# Altium schematic sheet CP2104.SchDoc(CP2104)
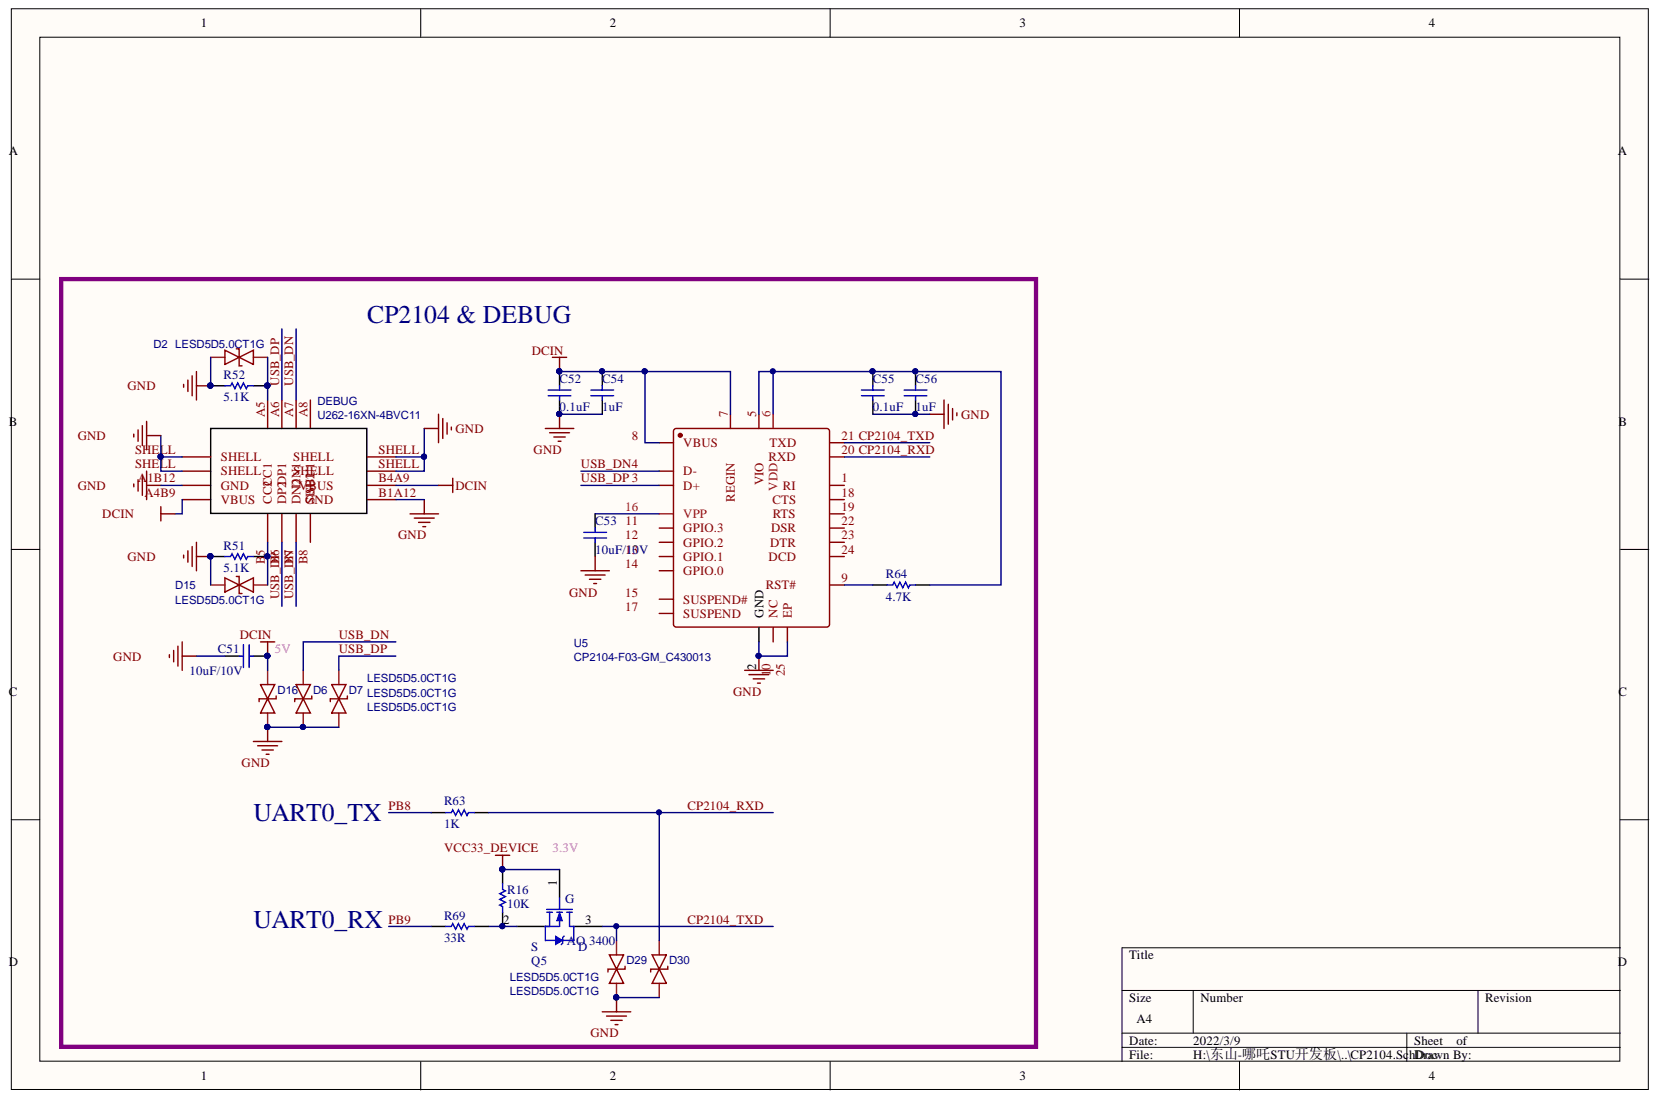
[diagram p0: CP2104.SchDoc]

## Parts
C51  10uF/10V  [0603]
C52  0.1uF  [0402]
C53  10uF/10V  [0603]
C54  1uF  [0402]
C55  0.1uF  [0402]
C56  1uF  [0402]
D2  LESD5D5.0CT1G  [SOD-523_L1.2-W0.8-LS1.6-BI]
D6  LESD5D5.0CT1G  [SOD-523_L1.2-W0.8-LS1.6-BI]
D7  LESD5D5.0CT1G  [SOD-523_L1.2-W0.8-LS1.6-BI]
D15  LESD5D5.0CT1G  [SOD-523_L1.2-W0.8-LS1.6-BI]
D16  LESD5D5.0CT1G  [SOD-523_L1.2-W0.8-LS1.6-BI]
D29  LESD5D5.0CT1G  [SOD-523_L1.2-W0.8-LS1.6-BI]
D30  LESD5D5.0CT1G  [SOD-523_L1.2-W0.8-LS1.6-BI]
DEBUG  U262-16XN-4BVC11  [TYPEC-12PIN - duplicate]
Q5  AO 3400  [SOT23]
R16  10K  [0402]
R51  5.1K  [0402]
R52  5.1K  [0402]
R63  1K  [0402]
R64  4.7K  [0402]
R69  33R  [0402]
U5  CP2104-F03-GM_C430013  [QFN-24_L4.0-W4.0-P0.50-TL-EP2.7]

## Nets
DCIN: C51.2 C52.1 C54.1 D16.2 DEBUG.A4B9(VBUS) DEBUG.B4A9 U5.7 U5.8(VBUS)
GND: C51.1 C52.2 C53.1 C54.2 C55.2 C56.2 D2.2 D6.1 D7.1 D15.2 D16.1 D29.2 D30.2 DEBUG.A1B12(GND) DEBUG.B1A12 DEBUG.SHELL R51.2 R52.2 U5.2(GND) U5.25(EP)
VCC33_DEVICE: Q5.1 R16.1
CP2104_RXD: D30.1 R63.2 U5.20
CP2104_TXD: D29.1 Q5.3 U5.21
PB8: R63.1
PB9: R69.1
USB_DN: D6.2 DEBUG.A7 DEBUG.B7(DN2) U5.4(D-)
USB_DP: D7.2 DEBUG.A6 DEBUG.B6(DP2) U5.3(D+)
NetC53_2: C53.2 U5.16(VPP)
NetC55_1: C55.1 C56.1 R64.2 U5.5 U5.6
NetD2_1: D2.1 DEBUG.A5 R52.1
NetD15_1: D15.1 DEBUG.B5(CC2) R51.1
NetQ5_2: Q5.2 R16.2 R69.2
NetR64_1: R64.1 U5.9

Not connected: DEBUG A8 B8(SBU2); U5 1 10(NC) 11(GPIO.3) 12(GPIO.2) 13(GPIO.1) 14(GPIO.0) 15(SUSPEND#) 17(SUSPEND) 18 19 22 23 24

## Library data of the parts
C51-C56 = Cap: Capacitor; PartNumber: CAP100NF; Component Kind: Standard; LatestRevisionDate: 17-Jul-2002; LatestRevisionNote: Re-released for DXP Platform.; Library Name: Miscellaneous Devices.IntLib; PackageReference: 0402; Published: 8-Jun-2000; Signal Integrity: Cap; Simulation: CAP; Publisher: Altium Limited
  C51 C53: Value: 10uF/10V
  C52 C55: Value: 0.1uF
  C54 C56: Value: 1uF
D2 D6 D7 D15 D16 D29 D30 = LESD5D5.0CT1G: BOM_Manufacturer: Leshan Radio; Contributor: LCSC; BOM_JLCPCB Part Class: Extended Part; BOM_Manufacturer Part: LESD5D5.0CT1G; BOM_Supplier: LCSC; BOM_Supplier Part: C383211; Component Kind: Standard; spicePre: D; spiceSymbolName: LESD5D5.0CT1G
DEBUG = U262-16XN-4BVC11: BOM_Manufacturer: XKB Enterprise; Contributor: LCSC; BOM_Manufacturer Part: U262-16XN-4BVC11; BOM_Supplier: LCSC; BOM_Supplier Part: C319148; Component Kind: Standard; Library Name: HM2.SchLib; spicePre: U; spiceSymbolName: U262-16XN-4BVC11
Q5 = 1110505011: Database Table Name: VALID$; Note: Pin 2 is electrically connected to pin 4.; 主计量单位名称: 只; 存货名称: 场效应管; 安全库存: 1346; 序号: 2666; 旧编码: 010605010; 旧规格型号: N沟道 AO 3400 SOT23; 规格型号: AO3400,SOT23,N沟道; Component Kind: Standard; DatasheetVersion: PD-9.814; LatestRevisionDate: 17-Jul-2002; LatestRevisionNote: Re-released for DXP Platform.; Library Name: Database_AD Library.DbLib; PackageDescription: TO, Thru-Hole, Vertical, Heatsink Mounted; 3 In-Line Leads; Pitch 2.54 mm; PackageReference: TO-220AB; PackageVersion: Feb-1997; Published: 8-Jun-2000; Simulation: IRF1010; VALUE: AO 3400; 存货编码: 1110505011; Publisher: Altium Limited
R16 R51 R52 R63 R64 R69 = Res1: Resistor; PartNumber: RES2.2K; Component Kind: Standard; LatestRevisionDate: 17-Jul-2002; LatestRevisionNote: Re-released for DXP Platform.; Library Name: Miscellaneous Devices.IntLib; PackageReference: 0402; Published: 8-Jun-2000; Signal Integrity: Res; Simulation: RESISTOR; Publisher: Altium Limited
  R16: Value: 10K
  R51 R52: Value: 5.1K
  R63: Value: 1K
  R64: Value: 4.7K
  R69: Value: 33R
U5 = CP2104-F03-GM_C430013: BOM_Manufacturer: SILICON LABS; Contributor: LCSC; BOM_JLCPCB Part Class: Extended Part; BOM_Manufacturer Part: CP2104-F03-GM; BOM_Supplier: LCSC; BOM_Supplier Part: C430013; Component Kind: Standard; Library Name: HM2.SchLib; spicePre: U; spiceSymbolName: CP2104-F03-GM_C430013
